# Altium schematic sheet H-9220 Backplane Board - Power (REV07).SchDoc
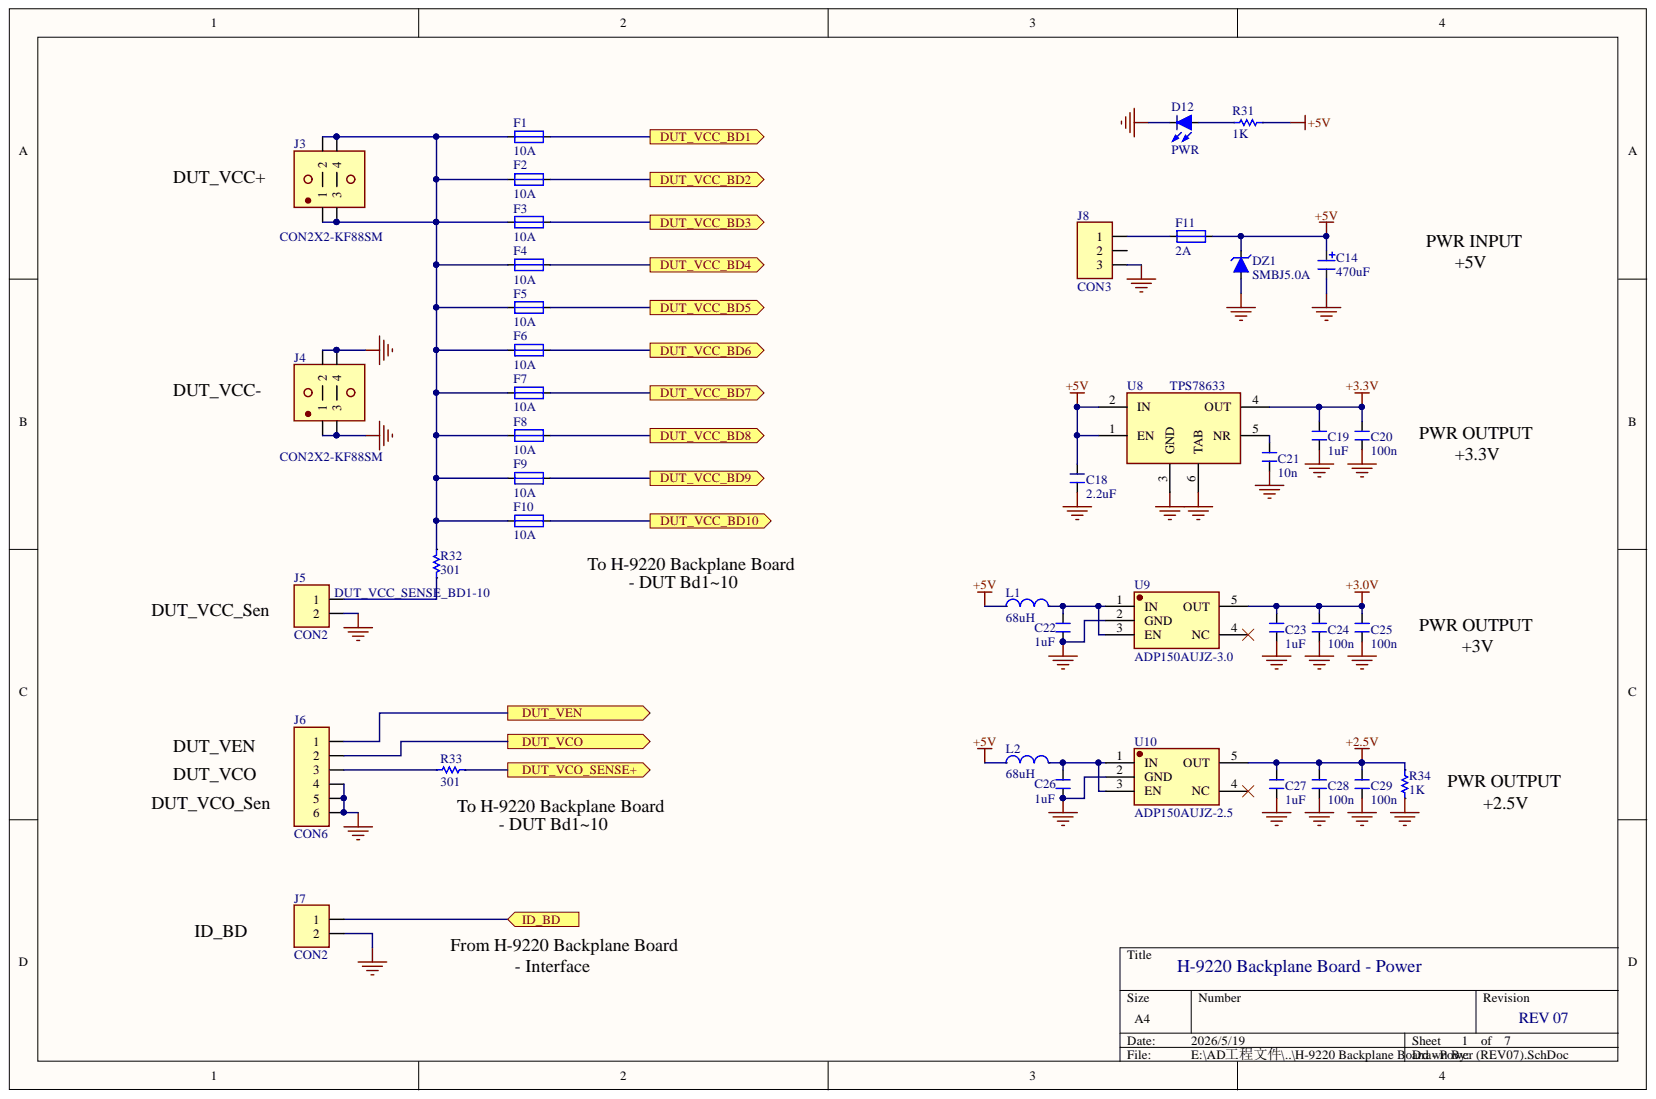
[diagram p0: H-9220 Backplane Board - Power (REV07).SchDoc]

## Parts
C14  470uF  [CASE-D (7343)]
C18  2.2uF  [C-0805]
C19  1uF  [C-0805]
C20  100n  [C-0603]
C21  10n  [C-0603]
C22  1uF  [C-0805]
C23  1uF  [C-0805]
C24  100n  [C-0603]
C25  100n  [C-0603]
C26  1uF  [C-0805]
C27  1uF  [C-0805]
C28  100n  [C-0603]
C29  100n  [C-0603]
D12  PWR  [LED-0805]
DZ1  SMBJ5.0A  [DO-214AA (SMB)]
F1  10A  [F1808_SOCKET]
F2  10A  [F1808_SOCKET]
F3  10A  [F1808_SOCKET]
F4  10A  [F1808_SOCKET]
F5  10A  [F1808_SOCKET]
F6  10A  [F1808_SOCKET]
F7  10A  [F1808_SOCKET]
F8  10A  [F1808_SOCKET]
F9  10A  [F1808_SOCKET]
F10  10A  [F1808_SOCKET]
F11  2A  [F1808_SOCKET]
J3  CON2X2-KF88SM  [KF88SM-16.0 2X2P]
J4  CON2X2-KF88SM  [KF88SM-16.0 2X2P]
J5  CON2  [CH3.96-2P]
J6  CON6  [CH3.96-6P]
J7  CON2  [CH3.96-2P]
J8  CON3  [AMP5.08-3P]
L1  68uH  [L1008]
L2  68uH  [L1008]
R31  1K  [R-0603]
R32  301  [R-0805]
R33  301  [R-0805]
R34  1K  [R-0603]
U8  TPS78633  [SOT223-6]
U9  ADP150AUJZ-3.0  [SOT23-5]
U10  ADP150AUJZ-2.5  [SOT23-5]

## Ports
DUT_VCC_BD1, DUT_VCC_BD2, DUT_VCC_BD3, DUT_VCC_BD4, DUT_VCC_BD5, DUT_VCC_BD6, DUT_VCC_BD7, DUT_VCC_BD8, DUT_VCC_BD9, DUT_VCC_BD10, DUT_VCO, DUT_VCO_SENSE+, DUT_VEN, ID_BD

## Nets
+2.5V: C27.1 C28.1 C29.1 R34.1 U10.5(OUT)
+3.0V: C23.1 C24.1 C25.1 U9.5(OUT)
+3.3V: C19.1 C20.1 U8.4(OUT)
+5V: C14.1 C18.1 DZ1.K F11.1 L1.1 L2.1 R31.1 U8.1(EN) U8.2(IN)
GND: C14.2 C18.2 C19.2 C20.2 C21.2 C22.2 C23.2 C24.2 C25.2 C26.2 C27.2 C28.2 C29.2 D12.K DZ1.A J4.1 J4.2 J4.3 J4.4 J5.2 J6.4 J6.5 J6.6 J7.2 J8.3 R34.2 U8.3(GND) U8.6(TAB) U9.2(GND) U10.2(GND)
DUT_VCC_BD1: F1.1 ; port DUT_VCC_BD1
DUT_VCC_BD2: F2.1 ; port DUT_VCC_BD2
DUT_VCC_BD3: F3.1 ; port DUT_VCC_BD3
DUT_VCC_BD4: F4.1 ; port DUT_VCC_BD4
DUT_VCC_BD5: F5.1 ; port DUT_VCC_BD5
DUT_VCC_BD6: F6.1 ; port DUT_VCC_BD6
DUT_VCC_BD7: F7.1 ; port DUT_VCC_BD7
DUT_VCC_BD8: F8.1 ; port DUT_VCC_BD8
DUT_VCC_BD9: F9.1 ; port DUT_VCC_BD9
DUT_VCC_BD10: F10.1 ; port DUT_VCC_BD10
DUT_VCO: J6.2 ; port DUT_VCO
DUT_VCO_SENSE+: R33.2 ; port DUT_VCO_SENSE+
DUT_VEN: J6.1 ; port DUT_VEN
ID_BD: J7.1 ; port ID_BD
NetC21_1: C21.1 U8.5(NR)
NetC22_1: C22.1 L1.2 U9.1(IN) U9.3(EN)
NetC26_1: C26.1 L2.2 U10.1(IN) U10.3(EN)
NetD12_A: D12.A R31.2
NetF1_2: F1.2 F2.2 F3.2 F4.2 F5.2 F6.2 F7.2 F8.2 F9.2 F10.2 J3.1 J3.2 J3.3 J3.4 R32.1
NetF11_2: F11.2 J8.1
NetJ5_1: J5.1 R32.2
NetJ6_3: J6.3 R33.1

Not connected: J8 2; U9 4(NC); U10 4(NC)

## Library data of the parts
C14 = Cap Pol: Polarized Capacitor (Surface Mount); Value: 10uF; Component Kind: Standard; LatestRevisionDate: 17-Jul-2002; LatestRevisionNote: Re-released for DXP Platform.; Library Name: HW SCH Lib.SCHLIB; PackageReference: C0805; Published: 8-Jun-2000; Publisher: Altium Limited
C18-C29 = CAP: Component Kind: Standard; Library Name: HW SCH Lib.SCHLIB
D12 = Diode LED: Typical RED, GREEN, YELLOW, AMBER GaAs LED; Component Kind: Standard; LatestRevisionDate: 17-Jul-2002; LatestRevisionNote: Re-released for DXP Platform.; Library Name: HW SCH Lib.SCHLIB; PackageDocument: Feb-2002; PackageReference: 3.2X1.6X1.1; Published: 8-Jun-2000; Publisher: Altium Limited
DZ1 = Diode Zener: Diode Zener; TVS; Component Kind: Standard; Library Name: HW SCH Lib.SCHLIB
F1-F11 = Fuse 1: Fuse; Component Kind: Standard; LatestRevisionDate: 17-Jul-2002; LatestRevisionNote: Re-released for DXP Platform.; Library Name: HW SCH Lib.SCHLIB; PackageReference: PIN-W2/E2.8; Published: 8-Jun-2000; Publisher: Altium Limited
L1 L2 = Inductor: Component Kind: Standard; Library Name: HW SCH Lib.SCHLIB
R31-R34 = RES: : 10K; Component Kind: Standard; Library Name: HW SCH Lib.SCHLIB
U9 U10 = ADP150AUJZ: Component Kind: Standard; Library Name: HW SCH Lib.SCHLIB
J3 J4 = CON2X2-KF88SM: Component Kind: Standard; Library Name: HW SCH Lib.SCHLIB
J5 J7 = CON2: Component Kind: Standard; Library Name: HW SCH Lib.SCHLIB
J6 = CON6: Component Kind: Standard; Library Name: HW SCH Lib.SCHLIB
J8 = CON3: Component Kind: Standard; Library Name: HW SCH Lib.SCHLIB
U8 = TPS78633: Component Kind: Standard; Library Name: HW SCH Lib.SCHLIB
